# Altium schematic sheet JD-Signal-SCH.SchDoc
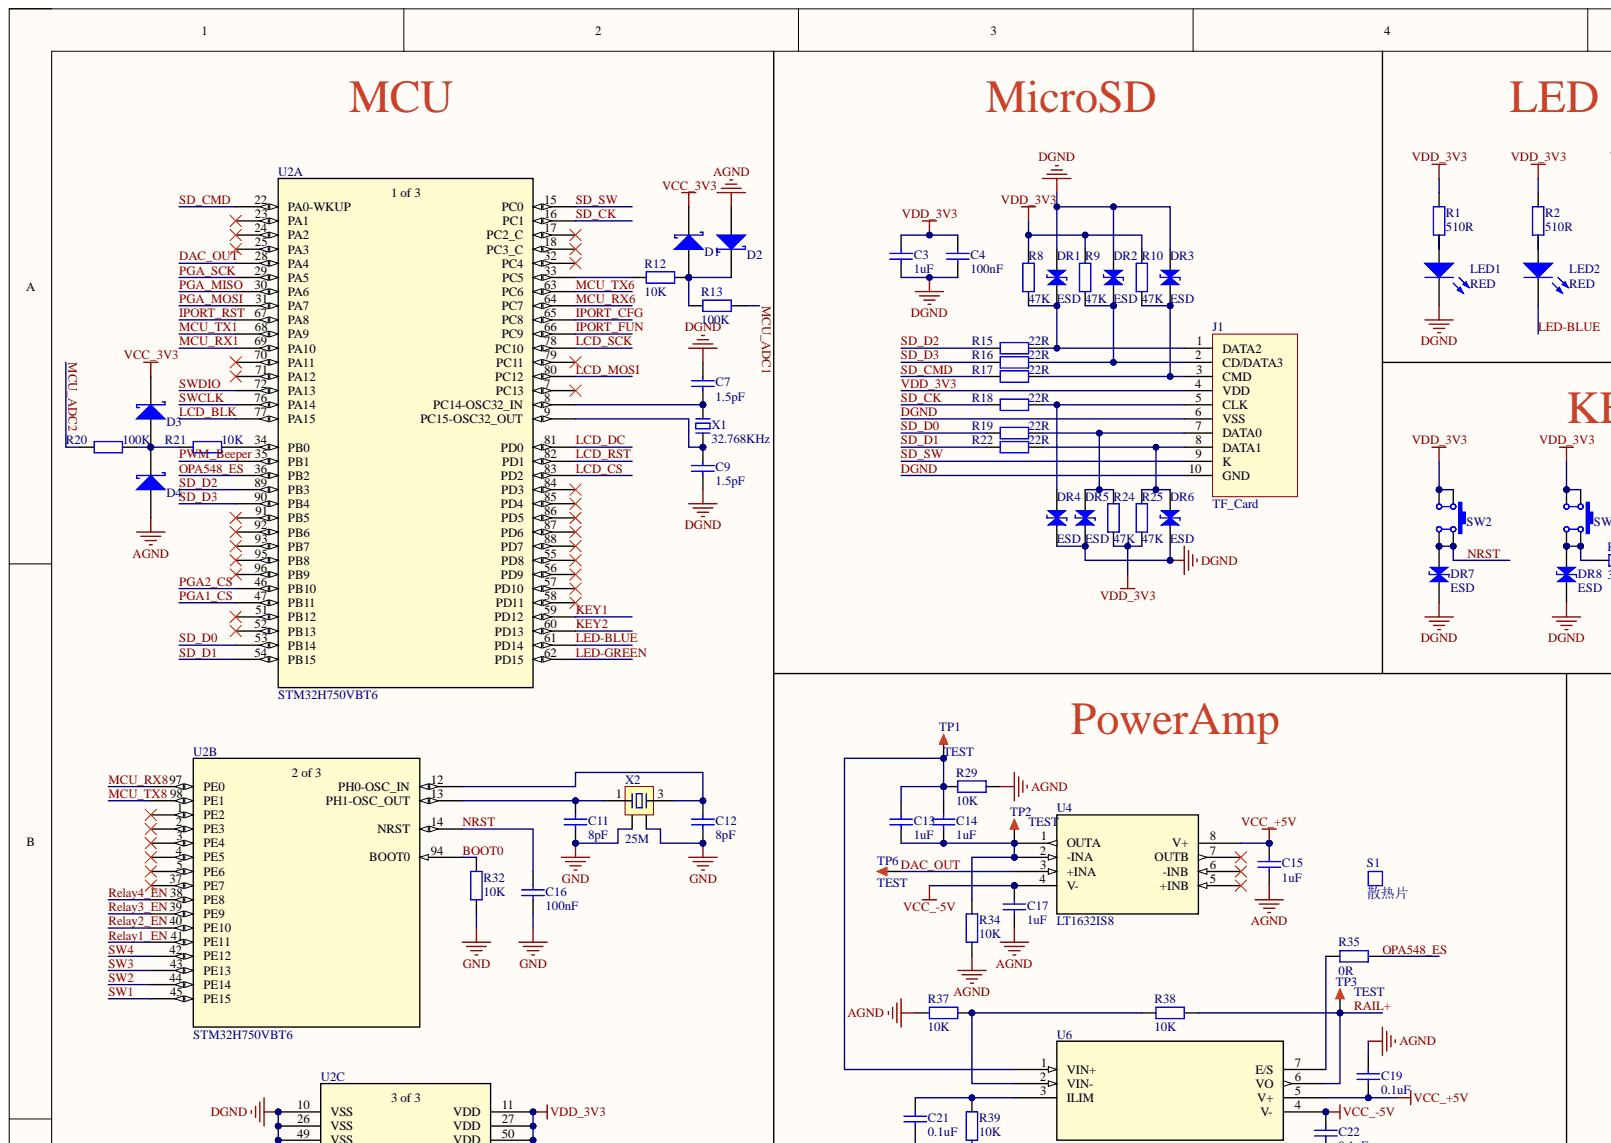
[diagram p0: JD-Signal-SCH.SchDoc, top left]
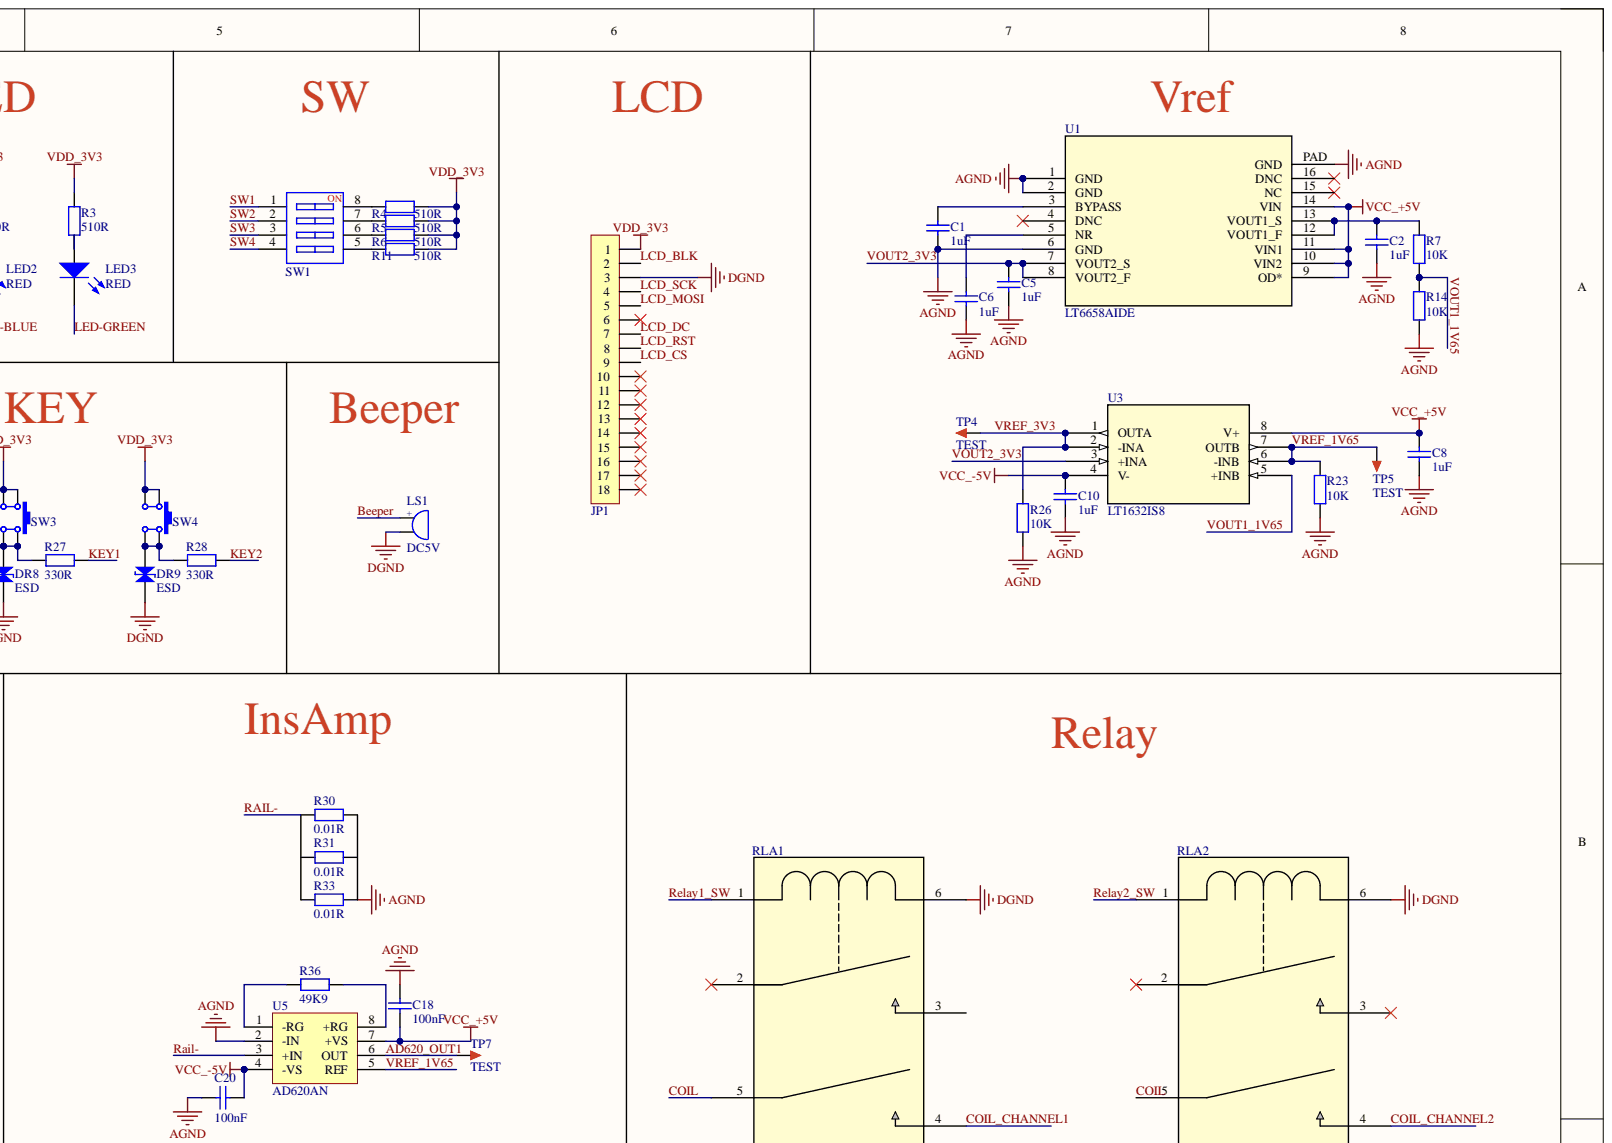
[diagram p1: JD-Signal-SCH.SchDoc, top right]
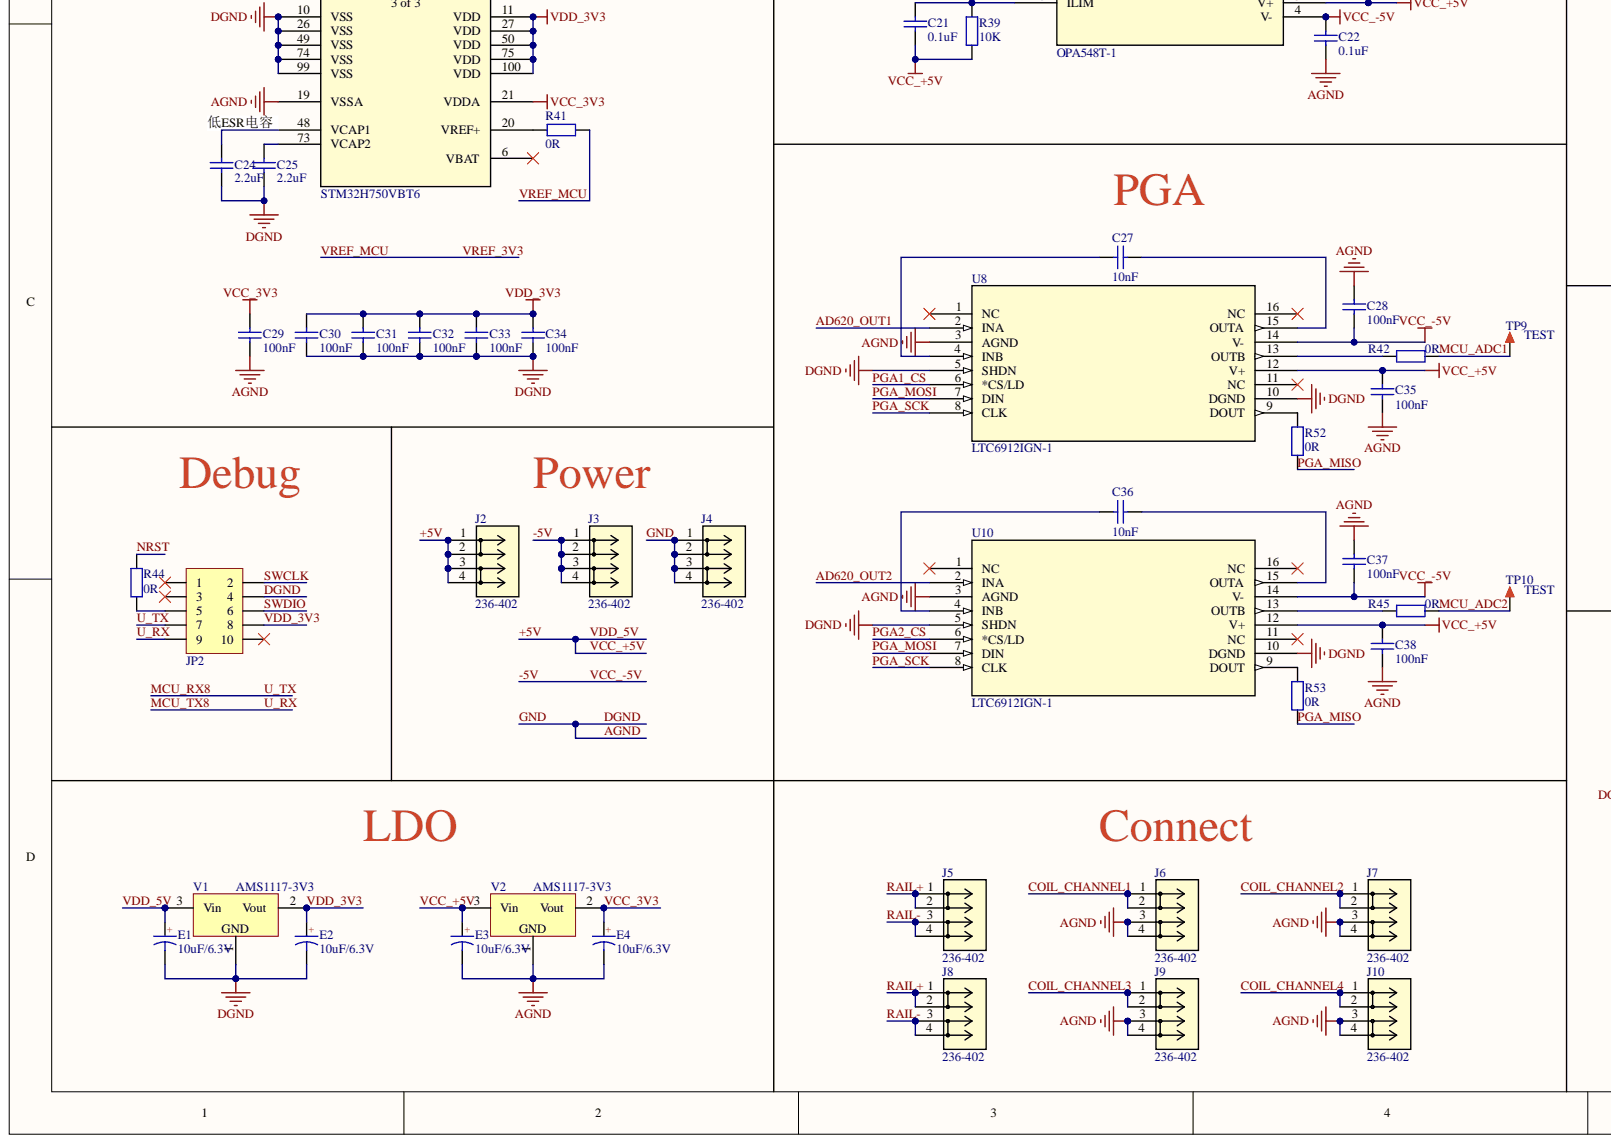
[diagram p2: JD-Signal-SCH.SchDoc, bottom left]
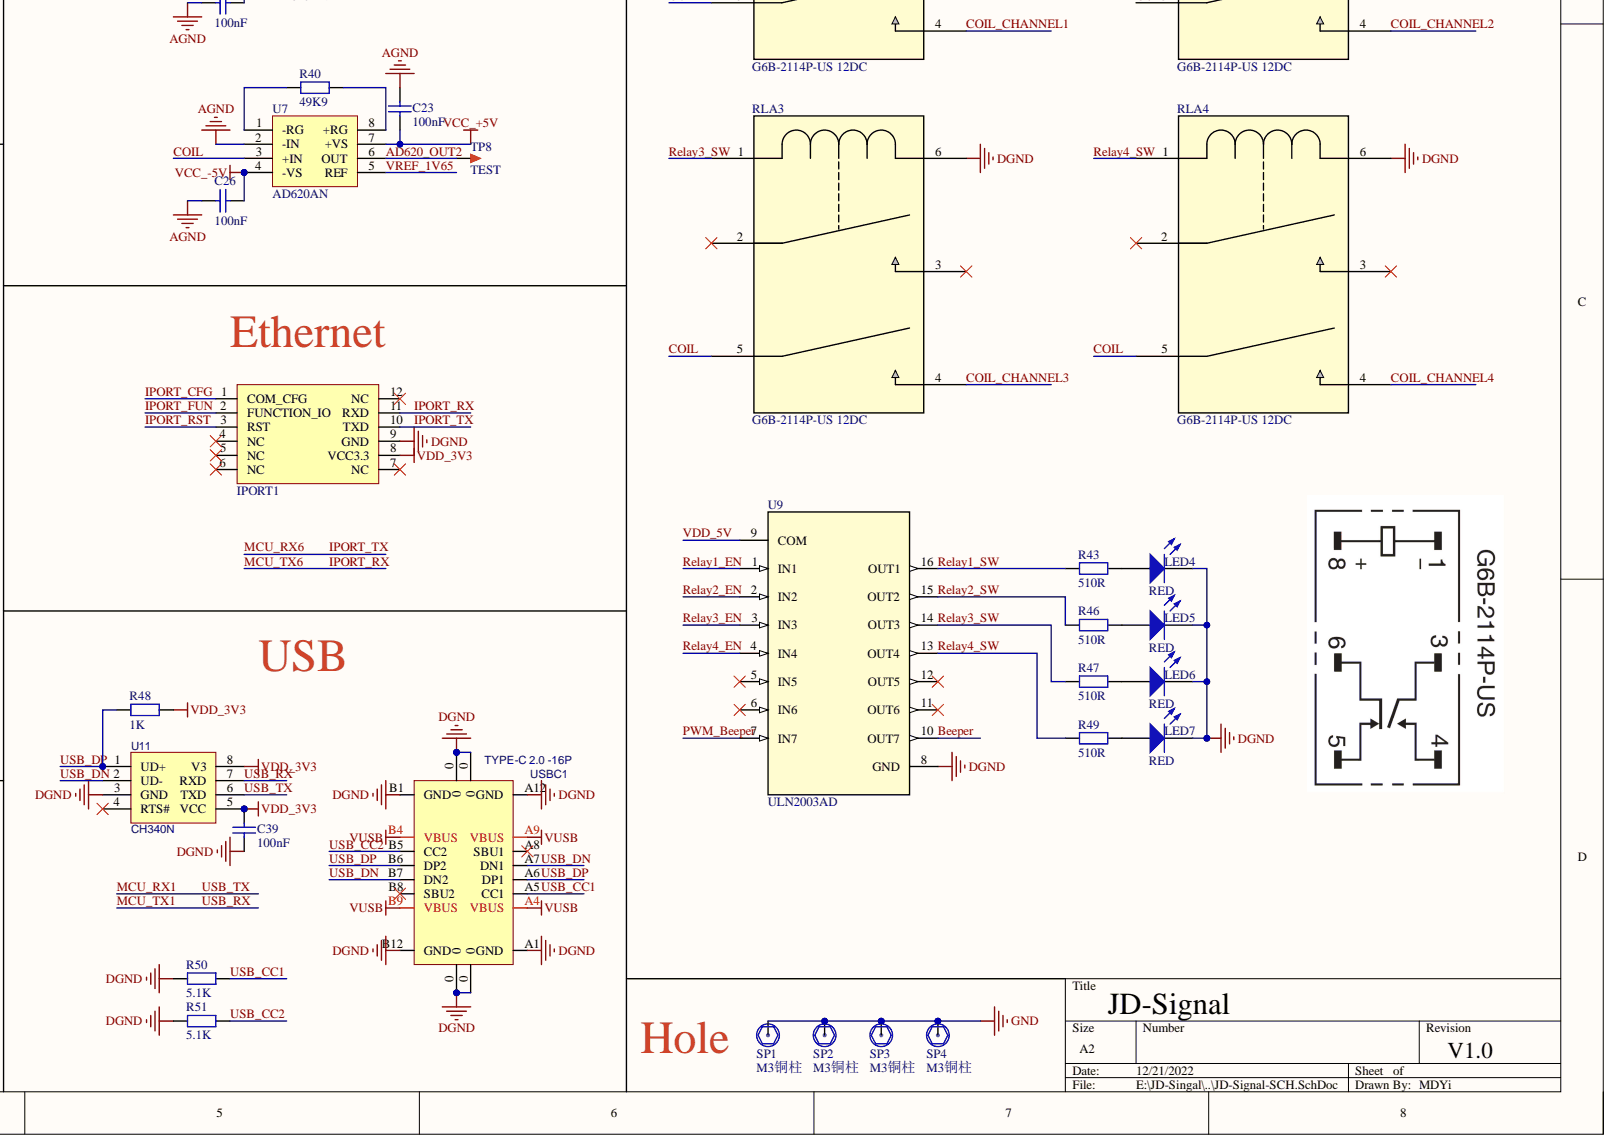
[diagram p3: JD-Signal-SCH.SchDoc, bottom right]

## Parts
C1  1uF  [C0603_M]
C2  1uF  [C0603_M]
C3  1uF  [C0603_M]
C4  100nF  [C0603_M]
C5  1uF  [C0603_M]
C6  1uF  [C0603_M]
C7  1.5pF  [C0603_M]
C8  1uF  [C0603_M]
C9  1.5pF  [C0603_M]
C10  1uF  [C0603_M]
C11  8pF  [C0603_M]
C12  8pF  [C0603_M]
C13  1uF  [C0603_M]
C14  1uF  [C0603_M]
C15  1uF  [C0603_M]
C16  100nF  [C0603_M]
C17  1uF  [C0603_M]
C18  100nF  [C0603_M]
C19  0.1uF  [C0603_M]
C20  100nF  [C0603_M]
C21  0.1uF  [C0603_M]
C22  0.1uF  [C0603_M]
C23  100nF  [C0603_M]
C24  2.2uF  [C0603_M]
C25  2.2uF  [C0603_M]
C26  100nF  [C0603_M]
C27  10nF  [C0603_M]
C28  100nF  [C0603_M]
C29  100nF  [C0603_M]
C30  100nF  [C0603_M]
C31  100nF  [C0603_M]
C32  100nF  [C0603_M]
C33  100nF  [C0603_M]
C34  100nF  [C0603_M]
C35  100nF  [C0603_M]
C36  10nF  [C0603_M]
C37  100nF  [C0603_M]
C38  100nF  [C0603_M]
C39  100nF  [C0603_M]
D1  VS10BQ015HM3  [SMB]  (value not drawn)
D2  VS10BQ015HM3  [SMB]  (value not drawn)
D3  VS10BQ015HM3  [SMB]  (value not drawn)
D4  VS10BQ015HM3  [SMB]  (value not drawn)
DR1  ESD  [0402]
DR2  ESD  [0402]
DR3  ESD  [0402]
DR4  ESD  [0402]
DR5  ESD  [0402]
DR6  ESD  [0402]
DR7  ESD  [0402]
DR8  ESD  [0402]
DR9  ESD  [0402]
E1  10uF/6.3V  [C_3216]
E2  10uF/6.3V  [C_3216]
E3  10uF/6.3V  [C_3216]
E4  10uF/6.3V  [C_3216]
IPORT1  IPORT-3  [PCBComponent_1]  (value not drawn)
J1  TF_Card  [Micro SD]
J2  236-402  [CONN_236-402_WAG]
J3  236-402  [CONN_236-402_WAG]
J4  236-402  [CONN_236-402_WAG]
J5  236-402  [CONN_236-402_WAG]
J6  236-402  [CONN_236-402_WAG]
J7  236-402  [CONN_236-402_WAG]
J8  236-402  [CONN_236-402_WAG]
J9  236-402  [CONN_236-402_WAG]
J10  236-402  [CONN_236-402_WAG]
JP1  CON-18P  [FPC0.5-WT-18P]  (value not drawn)
JP2  Header 5X2  [HDR2.54-LI-2x5P]  (value not drawn)
LED1  RED  [LED0603_R]
LED2  RED  [LED0603_R]
LED3  RED  [LED0603_R]
LED4  RED  [LED0603_R]
LED5  RED  [LED0603_R]
LED6  RED  [LED0603_R]
LED7  RED  [LED0603_R]
LS1  DC5V  [BEEP 5x9x5.5]
R1  510R  [R0603_M]
R2  510R  [R0603_M]
R3  510R  [R0603_M]
R4  510R  [R0603_M]
R5  510R  [R0603_M]
R6  510R  [R0603_M]
R7  10K  [R0603_M]
R8  47K  [R0603_M]
R9  47K  [R0603_M]
R10  47K  [R0603_M]
R11  510R  [R0603_M]
R12  10K  [R0603_M]
R13  100K  [R0603_M]
R14  10K  [R0603_M]
R15  22R  [R0603_M]
R16  22R  [R0603_M]
R17  22R  [R0603_M]
R18  22R  [R0603_M]
R19  22R  [R0603_M]
R20  100K  [R0603_M]
R21  10K  [R0603_M]
R22  22R  [R0603_M]
R23  10K  [R0603_M]
R24  47K  [R0603_M]
R25  47K  [R0603_M]
R26  10K  [R0603_M]
R27  330R  [R0603_M]
R28  330R  [R0603_M]
R29  10K  [R0603_M]
R30  0.01R  [HS25]
R31  0.01R  [HS25]
R32  10K  [R0603_M]
R33  0.01R  [HS25]
R34  10K  [R0603_M]
R35  0R  [R0603_M]
R36  49K9  [R0603_M]
R37  10K  [R0603_M]
R38  10K  [R0603_M]
R39  10K  [R0603_M]
R40  49K9  [R0603_M]
R41  0R  [R0603_M]
R42  0R  [R0603_M]
R43  510R  [R0603_L]
R44  0R  [R0603_M]
R45  0R  [R0603_M]
R46  510R  [R0603_L]
R47  510R  [R0603_L]
R48  1K  [R0603_L]
R49  510R  [R0603_L]
R50  5.1K  [R0603_L]
R51  5.1K  [R0603_L]
R52  0R  [R0603_M]
R53  0R  [R0603_M]
RLA1  G6B-2114P-US 12DC  [RELAY_G6B-2114P_OMR]
RLA2  G6B-2114P-US 12DC  [RELAY_G6B-2114P_OMR]
RLA3  G6B-2114P-US 12DC  [RELAY_G6B-2114P_OMR]
RLA4  G6B-2114P-US 12DC  [RELAY_G6B-2114P_OMR]
S1  散热片  [SRP16-24-25-P]
SP1  M3铜柱  [M3x4+6_N]
SP2  M3铜柱  [M3x4+6_N]
SP3  M3铜柱  [M3x4+6_N]
SP4  M3铜柱  [M3x4+6_N]
SW1  DIP-SW-4P  [SW DIP-4P]  (value not drawn)
SW2  4x4x1.5  [SW SMD-3*6*2.5]  (value not drawn)
SW3  4x4x1.5  [SW SMD-3*6*2.5]  (value not drawn)
SW4  4x4x1.5  [SW SMD-3*6*2.5]  (value not drawn)
TP1  TEST  [TEST 1.6]
TP2  TEST  [TEST 1.6]
TP3  TEST  [TEST 1.6]
TP4  TEST  [TEST 1.6]
TP5  TEST  [TEST 1.6]
TP6  TEST  [TEST 1.6]
TP7  TEST  [TEST 1.6]
TP8  TEST  [TEST 1.6]
TP9  TEST  [TEST 1.6]
TP10  TEST  [TEST 1.6]
U1  LT6658AIDE  [MSOP16]
U2  STM32H750VBT6  [LQFP100-14*14]  (parts A,B,C)
U3  LT1632IS8  [SO-8]
U4  LT1632IS8  [SO-8]
U5  AD620AN  [SOIC-8]
U6  OPA548T-1  [TO-220-7]
U7  AD620AN  [SOIC-8]
U8  LTC6912IGN-1  [SSOP16]
U9  ULN2003AD  [SOP16]
U10  LTC6912IGN-1  [SSOP-16]
U11  CH340N  [SOIC-8]
USBC1  TYPE-C 2.0 -16P  [USB-C-SMD_16P]
V1  AMS1117-3V3  [SOT89_L]
V2  AMS1117-3V3  [SOT89_L]
X1  32.768KHz  [XTAL-3215-2P]
X2  25M  [XTAL-3225-4P]

## Nets
VUSB: USBC1.A4(VBUS) USBC1.A9(VBUS) USBC1.B4(VBUS) USBC1.B9(VBUS)
+5V: C8.1 C15.2 C18.1 C19.1 C21.1 C23.1 C35.2 C38.2 E1.1 E3.1 J2.1 J2.2 J2.3 J2.4 R39.1 U1.9(OD*) U1.10(VIN2) U1.11(VIN1) U1.14(VIN) U3.8(V+) U4.8(V+) U5.7(+VS) U6.5(V+) U7.7(+VS) U8.12(V+) U9.9(COM) U10.12(V+) V1.3 V2.3
-5V: C10.2 C17.2 C20.1 C22.2 C26.1 C28.1 C37.1 J3.1 J3.2 J3.3 J3.4 U3.4(V-) U4.4(V-) U5.4(-VS) U6.4(V-) U7.4(-VS) U8.14(V-) U10.14(V-)
AD620_OUT1: TP7.1 U5.6(OUT) U8.2(INA)
AD620_OUT2: TP8.1 U7.6(OUT) U10.2(INA)
AGND: C1.1 C2.1 C3.1 C4.1 C5.1 C6.1 C7.1 C8.2 C9.1 C10.1 C11.1 C12.1 C15.1 C16.1 C17.1 C18.2 C19.2 C20.2 C22.1 C23.2 C24.1 C25.1 C26.2 C28.2 C29.1 C30.1 C31.1 C32.1 C33.1 C34.1 C35.1 C37.2 C38.1 C39.1 D2.1 D4.1 DR1.1 DR2.1 DR3.1 DR4.2 DR5.2 DR6.2 DR7.2 DR8.2 DR9.2 E1.2 E2.2 E3.2 E4.2 IPORT1.9(GND) J1.6(VSS) J1.10(GND) J4.1 J4.2 J4.3 J4.4 J6.3 J6.4 J7.3 J7.4 J9.3 J9.4 J10.3 J10.4 JP1.3 JP2.4 LED1.2 LED4.2 LED5.2 LED6.2 LED7.2 LS1.2 R14.1 R23.1 R26.1 R29.1 R32.1 R33.2 R34.1 R37.2 R50.2 R51.2 RLA1.6 RLA2.6 RLA3.6 RLA4.6 SP1.1 SP2.1 SP3.1 SP4.1 U1.1(GND) U1.2(GND) U1.6(GND) U1.PAD(GND) U2.10(VSS) U2.19(VSSA) U2.26(VSS) U2.49(VSS) U2.74(VSS) U2.99(VSS) U5.2(-IN) U7.2(-IN) U8.3(AGND) U8.5(SHDN) U8.10(DGND) U9.8(GND) U10.3(AGND) U10.5(SHDN) U10.10(DGND) U11.3(GND) USBC1.0 USBC1.A1(GND) USBC1.A12(GND) USBC1.B1(GND) USBC1.B12(GND) V1.1 V2.1 X2.2 X2.4
Beeper: LS1.1 U9.10(OUT7)
BOOT0: R32.2 U2.94(BOOT0)
COIL: RLA1.5 RLA2.5 RLA3.5 RLA4.5 U7.3(+IN)
COIL_CHANNEL1: J6.1 J6.2 RLA1.4
COIL_CHANNEL2: J7.1 J7.2 RLA2.4
COIL_CHANNEL3: J9.1 J9.2 RLA3.4
COIL_CHANNEL4: J10.1 J10.2 RLA4.4
DAC_OUT: TP6.1 U2.28(PA4) U4.3(+INA)
IPORT_CFG: IPORT1.1(COM_CFG) U2.65(PC8)
IPORT_FUN: IPORT1.2(FUNCTION_IO) U2.66(PC9)
IPORT_RST: IPORT1.3(RST) U2.67(PA8)
IPORT_RX: IPORT1.11(RXD) U2.63(PC6)
IPORT_TX: IPORT1.10(TXD) U2.64(PC7)
KEY1: R27.1 U2.59(PD12)
KEY2: R28.1 U2.60(PD13)
LCD_BLK: JP1.2 U2.77(PA15)
LCD_CS: JP1.9 U2.83(PD2)
LCD_DC: JP1.7 U2.81(PD0)
LCD_MOSI: JP1.5 U2.80(PC12)
LCD_RST: JP1.8 U2.82(PD1)
LCD_SCK: JP1.4 U2.78(PC10)
LED-BLUE: LED2.2 U2.61(PD14)
LED-GREEN: LED3.2 U2.62(PD15)
MCU_ADC1: R13.1 R42.2 TP9.1
MCU_ADC2: R20.2 R45.2 TP10.1
MCU_RX1: U2.69(PA10) U11.6(TXD)
MCU_RX8: JP2.7 U2.97(PE0)
MCU_TX1: U2.68(PA9) U11.7(RXD)
MCU_TX8: JP2.9 U2.98(PE1)
NRST: C16.2 DR7.1 R44.1 SW2.2 SW2.3 U2.14(NRST)
OPA548_ES: R35.2 U2.36(PB2)
PGA1_CS: U2.47(PB11) U8.6(*CS/LD)
PGA2_CS: U2.46(PB10) U10.6(*CS/LD)
PGA_MISO: R52.1 R53.1 U2.30(PA6)
PGA_MOSI: U2.31(PA7) U8.7(DIN) U10.7(DIN)
PGA_SCK: U2.29(PA5) U8.8(CLK) U10.8(CLK)
PWM_Beeper: U2.35(PB1) U9.7(IN7)
RAIL+: J5.1 J5.2 J8.1 J8.2 R38.1 TP3.1 U6.6(VO)
Rail-: J5.3 J5.4 J8.3 J8.4 R30.1 U5.3(+IN)
Relay1_EN: U2.41(PE11) U9.1(IN1)
Relay1_SW: R43.1 RLA1.1 U9.16(OUT1)
Relay2_EN: U2.40(PE10) U9.2(IN2)
Relay2_SW: R46.1 RLA2.1 U9.15(OUT2)
Relay3_EN: U2.39(PE9) U9.3(IN3)
Relay3_SW: R47.1 RLA3.1 U9.14(OUT3)
Relay4_EN: U2.38(PE8) U9.4(IN4)
Relay4_SW: R49.1 RLA4.1 U9.13(OUT4)
SD_CK: R18.2 U2.16(PC1)
SD_CMD: R17.2 U2.22(PA0-WKUP)
SD_D0: R19.2 U2.53(PB14)
SD_D1: R22.2 U2.54(PB15)
SD_D2: R15.2 U2.89(PB3)
SD_D3: R16.2 U2.90(PB4)
SD_SW: J1.9(K) U2.15(PC0)
SW1: SW1.1 U2.45(PE15)
SW2: SW1.2 U2.44(PE14)
SW3: SW1.3 U2.43(PE13)
SW4: SW1.4 U2.42(PE12)
SWCLK: JP2.2 U2.76(PA14)
SWDIO: JP2.6 U2.72(PA13)
USB_CC1: R50.1 USBC1.A5(CC1)
USB_CC2: R51.1 USBC1.B5(CC2)
USB_DN: U11.2(UD-) USBC1.A7(DN1) USBC1.B7(DN2)
USB_DP: R48.2 U11.1(UD+) USBC1.A6(DP1) USBC1.B6(DP2)
VCC_3V3: C29.2 D1.2 D3.2 E4.1 U2.21(VDDA) V2.2
VDD_3V3: C3.2 C4.2 C30.2 C31.2 C32.2 C33.2 C34.2 C39.2 E2.1 IPORT1.8(VCC3.3) J1.4(VDD) JP1.1 JP2.8 R1.2 R2.2 R3.2 R4.1 R5.1 R6.1 R8.1 R9.1 R10.1 R11.1 R24.2 R25.2 R48.1 SW2.1 SW2.4 SW3.1 SW3.4 SW4.1 SW4.4 U2.11(VDD) U2.27(VDD) U2.50(VDD) U2.75(VDD) U2.100(VDD) U11.5(VCC) U11.8(V3) V1.2
VOUT1_1V65: R7.1 R14.2 U3.5(+INB)
VOUT2_3V3: C5.2 U1.7(VOUT2_S) U1.8(VOUT2_F) U3.3(+INA)
VREF_1V65: R23.2 TP5.1 U3.6(-INB) U3.7(OUTB) U5.5(REF) U7.5(REF)
VREF_3V3: R26.2 R41.1 TP4.1 U3.1(OUTA) U3.2(-INA)
NetC1_2: C1.2 U1.3(BYPASS)
NetC2_2: C2.2 R7.2 U1.12(VOUT1_F) U1.13(VOUT1_S)
NetC6_2: C6.2 U1.5(NR)
NetC7_2: C7.2 U2.8(PC14-OSC32_IN) X1.2
NetC9_2: C9.2 U2.9(PC15-OSC32_OUT) X1.1
NetC11_2: C11.2 U2.13(PH1-OSC_OUT) X2.1
NetC12_2: C12.2 U2.12(PH0-OSC_IN) X2.3
NetC13_1: C13.1 C14.1 R29.2 TP1.1 U6.1(VIN+)
NetC13_2: C13.2 C14.2 R34.2 TP2.1 U4.1(OUTA) U4.2(-INA)
NetC21_2: C21.2 R39.2 U6.3(ILIM)
NetC24_2: C24.2 U2.48(VCAP1)
NetC25_2: C25.2 U2.73(VCAP2)
NetC27_1: C27.1 U8.15(OUTA)
NetC27_2: C27.2 U8.4(INB)
NetC36_1: C36.1 U10.15(OUTA)
NetC36_2: C36.2 U10.4(INB)
NetD1_1: D1.1 D2.2 R12.1 R13.2
NetD3_1: D3.1 D4.2 R20.1 R21.2
NetDR1_2: DR1.2 J1.1(DATA2) R8.2 R15.1
NetDR2_2: DR2.2 J1.2(CD/DATA3) R9.2 R16.1
NetDR3_2: DR3.2 J1.3(CMD) R10.2 R17.1
NetDR4_1: DR4.1 J1.5(CLK) R18.1
NetDR5_1: DR5.1 J1.7(DATA0) R19.1 R24.1
NetDR6_1: DR6.1 J1.8(DATA1) R22.1 R25.1
NetDR8_1: DR8.1 R27.2 SW3.2 SW3.3
NetDR9_1: DR9.1 R28.2 SW4.2 SW4.3
NetJP2_5: JP2.5 R44.2
NetLED1_1: LED1.1 R1.1
NetLED2_1: LED2.1 R2.1
NetLED3_1: LED3.1 R3.1
NetLED4_1: LED4.1 R43.2
NetLED5_1: LED5.1 R46.2
NetLED6_1: LED6.1 R47.2
NetLED7_1: LED7.1 R49.2
NetR4_2: R4.2 SW1.8
NetR5_2: R5.2 SW1.7
NetR6_2: R6.2 SW1.6
NetR11_2: R11.2 SW1.5
NetR12_2: R12.2 U2.33(PC5)
NetR21_1: R21.1 U2.34(PB0)
NetR35_1: R35.1 U6.7(E/S)
NetR36_1: R36.1 U5.8(+RG)
NetR36_2: R36.2 U5.1(-RG)
NetR37_1: R37.1 R38.2 U6.2(VIN-)
NetR40_1: R40.1 U7.8(+RG)
NetR40_2: R40.2 U7.1(-RG)
NetR41_2: R41.2 U2.20(VREF+)
NetR42_1: R42.1 U8.13(OUTB)
NetR45_1: R45.1 U10.13(OUTB)
NetR52_2: R52.2 U8.9(DOUT)
NetR53_2: R53.2 U10.9(DOUT)

Not connected: IPORT1 4(NC) 5(NC) 6(NC) 7(NC) 12(NC); JP1 6 10 11 12 13 14 15 16 17 18; JP2 1 3 10; R30 2; R31 1 2; R33 1; RLA1 2 3; RLA2 2 3; RLA3 2 3; RLA4 2 3; U1 4(DNC) 15(NC) 16(DNC); U2 1(PE2) 2(PE3) 3(PE4) 4(PE5) 5(PE6) 6(VBAT) 7(PC13) 17(PC2_C) 18(PC3_C) 23(PA1) 24(PA2) 25(PA3) 32(PC4) 37(PE7) 51(PB12) 52(PB13) 55(PD8) 56(PD9) 57(PD10) 58(PD11) 70(PA11) 71(PA12) 79(PC11) 84(PD3) 85(PD4) 86(PD5) 87(PD6) 88(PD7) 91(PB5) 92(PB6) 93(PB7) 95(PB8) 96(PB9); U4 5(+INB) 6(-INB) 7(OUTB); U8 1(NC) 11(NC) 16(NC); U9 5(IN5) 6(IN6) 11(OUT6) 12(OUT5); U10 1(NC) 11(NC) 16(NC); U11 4(RTS#); USBC1 A8(SBU1) B8(SBU2)

## Library data of the parts
C1-C39 = C: 贴片电容/MLCC电容; BOM名称: 无极性贴片电容; 联系下单店铺卖家 免费帮你查找封装: 联系下单店铺卖家 免费技术支持; Component Kind: Standard; Library Name: 0.1 - 电阻-电容-电感-保险丝-晶振-晶体-磁珠-电位器-法拉电容-馈通电容.SchLib
D1-D4 = D-Schottky: 肖特基二极管; 当前库版本V2022.10.7 @淘宝卖家: 最新版本发布https://shop463601444.taobao.com; Component Kind: Standard; Library Name: 0.2 - 二极管-TVS-ESD-整流桥-放电管.SchLib
DR1-DR9 = DR: 瞬态电压抑制二极管; 当前库版本V2022.10.7 @淘宝卖家: 最新版本发布https://shop463601444.taobao.com; BOM名称: 瞬态电压抑制二极管; Component Kind: Standard; Library Name: 0.2 - 二极管-TVS-ESD-整流桥-放电管.SchLib
E1-E4 = CT: 贴片钽电容; 联系下单店铺卖家 免费帮你查找封装: 联系下单店铺卖家 免费技术支持; BOM名称: 贴片钽电容; Component Kind: Standard; Library Name: 0.1 - 电阻-电容-电感-保险丝-晶振-晶体-磁珠-电位器-法拉电容-馈通电容.SchLib
IPORT1 = IPORT-3: BOM名称: 12P连接器; 封装库来自淘宝卖家 ID：黄晶宝石: 其他店铺购入均为盗版假冒封装库; 联系卖家 免费查找封装: 唯一出售店铺网址https://shop463601444.taobao.com; Component Kind: Standard; Description: IPORT-3; Library Name: Temperature-SCHLIB.SchLib
J1 = TF_Card: TF卡座; 当前库版本V2022.10.7 @淘宝卖家: 最新版本发布https://shop463601444.taobao.com; BOM名称: TF卡座; Component Kind: Standard; Library Name: 0.6 - 电源_音频_USB Type-C_HDMI_XT60_5557_D-SUB_RJ45_SD-TF_SIM插座.SchLib
J2-J10 = 236-402: No Description Available; Mfr_Name: Wago; Component Kind: Standard; Library Name: 236-402.SchLib; Manufacturer_Part_Number: 236-402; RefDes: RefDes; Type: DEV; Copyright: Copyright (C) 2022 Ultra Librarian. All rights reserved.
JP1 = Header 18: 18P连接器; 封装库来自淘宝卖家：黄晶宝石: 获取最新版本https://shop463601444.taobao.com; BOM名称: 18P连接器; Component Kind: Standard; Library Name: 5.0 - 1.00mm连接器(FPC1.0_SH1.0_1.0排针排母).SchLib
JP2 = Header 5X2: 5*2P接插件; 封装库来自淘宝卖家ID：黄晶宝石: 其他店铺购入均为盗版封装库，请立即申请退款，本店现金奖励50~200元; 联系卖家，免费查找封装: 唯一出售店铺网址https://shop463601444.taobao.com; BOM名称: 5*2P接插件; Component Kind: Standard; Library Name: 5.5 - 2.54mm连接器(KF2EDGK_XH2.54_2.54排针排母).SchLib
LED1-LED7 = LED: 贴片LED; 封装库来自淘宝卖家：黄晶宝石: 其他店铺购入均为盗版封装库，无更新权益，退款现金奖励200~1000元; BOM名称: 贴片LED; Component Kind: Standard; Library Name: 0.3 - LED发光二极管-IR二极管_接收管-光耦.SchLib
LS1 = BEEP: 蜂鸣器; 联系下单店铺卖家 免费技术支持: 联系下单店铺卖家 免费帮你查找封装; BOM名称: 蜂鸣器; Component Kind: Standard; Library Name: 0.5 - 按键_开关_蜂鸣器_电池_测试点_Mark点_铜柱.SchLib
R1-R53 = R: 贴片电阻; 当前库版本V2022.10.7 @黄晶宝石: 最新版本发布https://shop463601444.taobao.com; BOM名称: 贴片电阻; Component Kind: Standard; Library Name: 0.1 - 电阻-电容-电感-保险丝-晶振-晶体-磁珠-电位器-法拉电容-馈通电容.SchLib
RLA1-RLA4 = G6B-2114P-US 12DC: No Description Available; Mfr_Name: Omron; Component Kind: Standard; Library Name: G6B-2114P-US12DC.SchLib; Manufacturer_Part_Number: G6B-2114P-US 12DC; RefDes: RefDes; Type: DEV; Copyright: Copyright (C) 2022 Ultra Librarian. All rights reserved.
S1 = S1: 联系下单店铺卖家 免费技术支持: 联系下单店铺卖家 免费帮你查找封装; BOM名称: 散热片; Component Kind: Standard; Description: 散热片; Library Name: 0.5 - 按键_开关_蜂鸣器_电池_测试点_Mark点_铜柱.SchLib
SP1-SP4 = 铜柱加头大焊盘: 铜柱; 联系下单店铺卖家 免费技术支持: 联系下单店铺卖家 免费帮你查找封装; BOM名称: 铜柱; Component Kind: Standard; Library Name: 0.5 - 按键_开关_蜂鸣器_电池_测试点_Mark点_铜柱.SchLib
SW1 = DIP-SW-4P: 4路编码开关; 联系下单店铺卖家 免费技术支持: 联系下单店铺卖家 免费帮你查找封装; BOM名称: 4路编码开关; Component Kind: Standard; Library Name: 0.5 - 按键_开关_蜂鸣器_电池_测试点_Mark点_铜柱.SchLib
SW2-SW4 = SW SMD-4x4: 贴片4x4轻触开关; 联系下单店铺卖家 免费技术支持: 联系下单店铺卖家 免费帮你查找封装; BOM名称: 贴片4x4轻触开关; Component Kind: Standard; Library Name: 0.5 - 按键_开关_蜂鸣器_电池_测试点_Mark点_铜柱.SchLib
TP1-TP10 = TEST: 测试点; 联系下单店铺卖家 免费技术支持: 联系下单店铺卖家 免费帮你查找封装; BOM名称: 测试点; Component Kind: Standard; Library Name: 0.5 - 按键_开关_蜂鸣器_电池_测试点_Mark点_铜柱.SchLib
U1 = LT6658AIDE: No Description Available; Component Kind: Standard; Library Name: LT6658AIDE.SchLib; RefDes: RefDes; Type: DEV; Copyright: Copyright (C) 2021 Accelerated Designs. All rights reserved
U2 = STM32H750VBT6: No Description Available; Mfr_Name: STMicroelectronics; Component Kind: Standard; Library Name: STM32H750VBT6.SchLib; Manufacturer_Part_Number: STM32H750VBT6; RefDes: RefDes; Type: DEV; Copyright: Copyright (C) 2022 Ultra Librarian. All rights reserved.
U3 U4 = LT1632IS8: No Description Available; Vendor: Linear Technology; Component Kind: Standard; Library Name: LT1632IS8.SchLib; Manufacturer_Part_Number: lt1632is8; RefDes: RefDes; Type: DEV; Copyright: Copyright (C) 2021 Accelerated Designs. All rights reserved
U5 U7 = AD620AN: CI-AD620AN; 封装库来自淘宝卖家：黄晶宝石: 获取最新版本库文件https://shop463601444.taobao.com; Component Kind: Standard; Library Name: 1.5 - 精密运放-比较器.SchLib
U6 = OPA548T-1: No Description Available; Mfr_Name: Texas Instruments; Component Kind: Standard; Library Name: OPA548T-1.SchLib; Manufacturer_Part_Number: OPA548T-1; RefDes: RefDes; Type: DEV; Copyright: Copyright (C) 2022 Ultra Librarian. All rights reserved.
U8 U10 = LTC6912IGN-1: No Description Available; Vendor: Linear Technology; Component Kind: Standard; Library Name: LTC6912IGN-1.SchLib; Manufacturer_Part_Number: ltc6912ign-1; RefDes: RefDes; Type: DEV; Copyright: Copyright (C) 2021 Accelerated Designs. All rights reserved
U9 = ULN2003AD: No Description Available; Mfr_Name: Texas Instruments; Component Kind: Standard; Library Name: ULN2003AD.SchLib; Manufacturer_Part_Number: ULN2003AD; RefDes: RefDes; Type: DEV; Copyright: Copyright (C) 2022 Ultra Librarian. All rights reserved.
U11 = CH340N: USB转串口芯片, 内置晶振; 当前库版本V2022.10.7 @淘宝卖家: 最新版本发布https://shop463601444.taobao.com; 芯片厂商: WCH(南京沁恒); Component Kind: Standard; Library Name: 0.8 - 常见芯片.SchLib
USBC1 = USB2.0_Type-C_16Pin: USB2.0_Type-C_16Pin母座; 当前库版本V2022.10.7 @淘宝卖家: 最新版本发布https://shop463601444.taobao.com; Component Kind: Standard; Library Name: 0.6 - 电源_音频_USB Type-C_HDMI_XT60_5557_D-SUB_RJ45_SD-TF_SIM插座.SchLib
V1 V2 = AMS1117_SOT89: 三端稳压芯片; BOM名称: 稳压芯片; 当前库版本V2022.10.7 @淘宝卖家: 最新版本发布https://shop463601444.taobao.com; Component Kind: Standard; Library Name: 0.7 - 电源类芯片.SchLib
X1 = XTAL-2P: 2脚无源晶振(谐振器）; 当前库版本V2022.10.7 @黄晶宝石: 最新版本发布https://shop463601444.taobao.com; BOM名称: 2脚无源晶振(谐振器）; Component Kind: Standard; Library Name: 0.1 - 电阻-电容-电感-保险丝-晶振-晶体-磁珠-电位器-法拉电容-馈通电容.SchLib
X2 = XTAL-4P: 4脚无源晶振(谐振器）; 当前库版本V2022.10.7 @黄晶宝石: 最新版本发布https://shop463601444.taobao.com; BOM名称: 4脚无源晶振(谐振器）; Component Kind: Standard; Library Name: 0.1 - 电阻-电容-电感-保险丝-晶振-晶体-磁珠-电位器-法拉电容-馈通电容.SchLib
